# Altium schematic sheet Sheet6.SchDoc
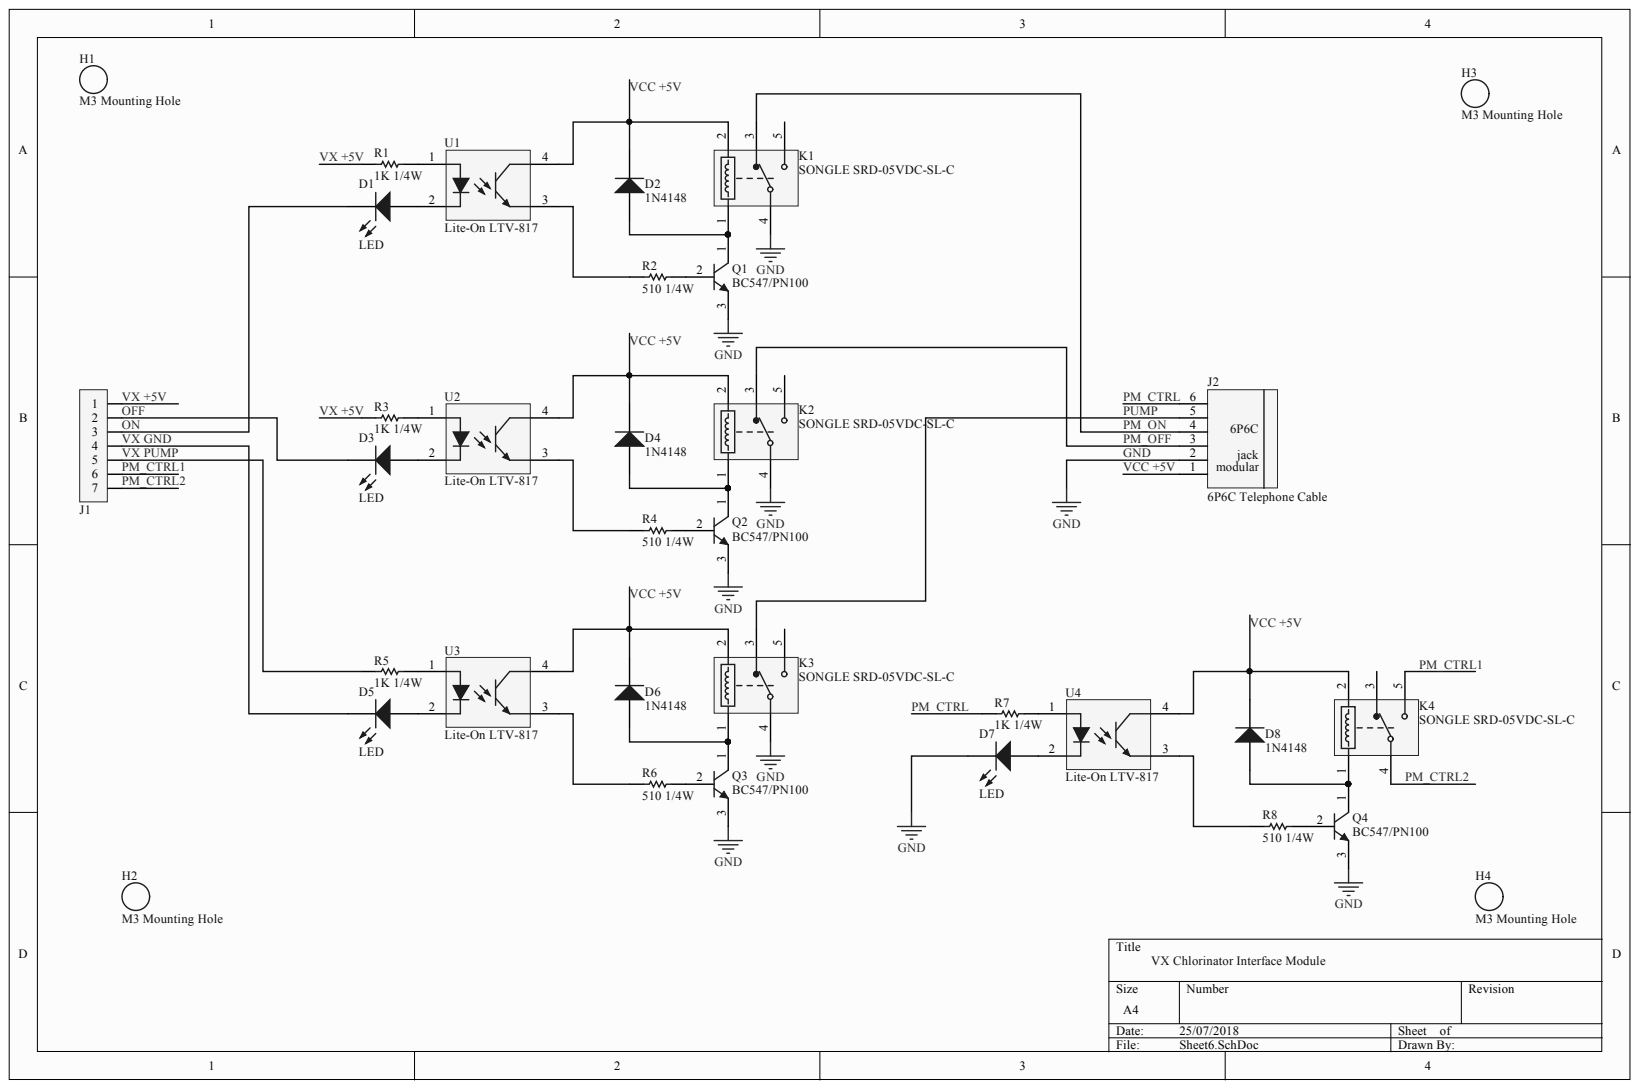
[diagram p0: Sheet6.SchDoc]

## Parts
D1  LED  [PCB-6hmn14p3euwrtpae4j9f-1]
D2  1N4148  [PCB-rs5tsfd40ie4dftnk2d5-1]
D3  LED  [PCB-6hmn14p3euwrtpae4j9f-1]
D4  1N4148  [PCB-rs5tsfd40ie4dftnk2d5-1]
D5  LED  [PCB-6hmn14p3euwrtpae4j9f-1]
D6  1N4148  [PCB-rs5tsfd40ie4dftnk2d5-1]
D7  LED  [PCB-6hmn14p3euwrtpae4j9f-1]
D8  1N4148  [PCB-rs5tsfd40ie4dftnk2d5-1]
H1  M3 Mounting Hole  [PCB-sb9r54v1ukudlsmquqqd-1]
H2  M3 Mounting Hole  [PCB-sb9r54v1ukudlsmquqqd-1]
H3  M3 Mounting Hole  [PCB-sb9r54v1ukudlsmquqqd-1]
H4  M3 Mounting Hole  [PCB-sb9r54v1ukudlsmquqqd-1]
J1  7pin header  [PCBComponent_1 - duplicate]  (value not drawn)
J2  6P6C Telephone Cable  [PCB-617631-1]
K1  SONGLE SRD-05VDC-SL-C  [PCB-g2fdo3sb6id1q29ao90z-1]
K2  SONGLE SRD-05VDC-SL-C  [PCB-g2fdo3sb6id1q29ao90z-1]
K3  SONGLE SRD-05VDC-SL-C  [PCB-g2fdo3sb6id1q29ao90z-1]
K4  SONGLE SRD-05VDC-SL-C  [PCB-g2fdo3sb6id1q29ao90z-1]
Q1  BC547/PN100  [ONSC-TO-92-3-29-11_AM_BL]
Q2  BC547/PN100  [ONSC-TO-92-3-29-11_AM_BL]
Q3  BC547/PN100  [ONSC-TO-92-3-29-11_AM_BL]
Q4  BC547/PN100  [ONSC-TO-92-3-29-11_AM_BL]
R1  1K 1/4W  [PCB-b0svi7yxgb64se8nuirk-1]
R2  510 1/4W  [PCB-b0svi7yxgb64se8nuirk-1]
R3  1K 1/4W  [PCB-b0svi7yxgb64se8nuirk-1]
R4  510 1/4W  [PCB-b0svi7yxgb64se8nuirk-1]
R5  1K 1/4W  [PCB-b0svi7yxgb64se8nuirk-1]
R6  510 1/4W  [PCB-b0svi7yxgb64se8nuirk-1]
R7  1K 1/4W  [PCB-b0svi7yxgb64se8nuirk-1]
R8  510 1/4W  [PCB-b0svi7yxgb64se8nuirk-1]
U1  Lite-On LTV-817  Manufacturer Part Number: LTV-817  [PCBComponent_1 - duplicate]
U2  Lite-On LTV-817  Manufacturer Part Number: LTV-817  [PCBComponent_1 - duplicate]
U3  Lite-On LTV-817  Manufacturer Part Number: LTV-817  [PCBComponent_1 - duplicate]
U4  Lite-On LTV-817  Manufacturer Part Number: LTV-817  [PCBComponent_1 - duplicate]

## Nets
GND: D7.2 J2.2 K1.4(COM) K2.4(COM) K3.4(COM) Q1.3 Q2.3 Q3.3 Q4.3
OFF: D3.2 J1.2
ON: D1.2 J1.3
PM_CTRL: J2.6 R7.2
PM_CTRL1: J1.6 K4.5(NO)
PM_CTRL2: J1.7 K4.4(COM)
PM_OFF: J2.3 K2.3(NC)
PM_ON: J2.4 K1.3(NC)
PUMP: J2.5 K3.3(NC)
VCC +5V: D2.2 D4.2 D6.2 D8.2 J2.1 K1.2(Coil2) K2.2(Coil2) K3.2(Coil2) K4.2(Coil2) U1.4 U2.4 U3.4 U4.4
VX +5V: J1.1 R1.2 R3.2
VX GND: D5.2 J1.4
VX PUMP: J1.5 R5.2
NetD1_1: D1.1 U1.2
NetD2_1: D2.1 K1.1(Coil1) Q1.1
NetD3_1: D3.1 U2.2
NetD4_1: D4.1 K2.1(Coil1) Q2.1
NetD5_1: D5.1 U3.2
NetD6_1: D6.1 K3.1(Coil1) Q3.1
NetD7_1: D7.1 U4.2
NetD8_1: D8.1 K4.1(Coil1) Q4.1
NetQ1_2: Q1.2 R2.2
NetQ2_2: Q2.2 R4.2
NetQ3_2: Q3.2 R6.2
NetQ4_2: Q4.2 R8.2
NetR1_1: R1.1 U1.1
NetR2_1: R2.1 U1.3
NetR3_1: R3.1 U2.1
NetR4_1: R4.1 U2.3
NetR5_1: R5.1 U3.1
NetR6_1: R6.1 U3.3
NetR7_1: R7.1 U4.1
NetR8_1: R8.1 U4.3

Not connected: K1 5(NO); K2 5(NO); K3 5(NO); K4 3(NC)

## Library data of the parts
D1 D3 D5 D7 = CMP-9d1f5758637d42d8-1: TLHR5205 Red LED, 625 nm 5mm (T-1 3/4), Round Lens Through Hole package; Forward Voltage: 2.00 V; Lead-Free Status: Lead Free; Lead Length: 24.0 mm; Luminous Intensity: 70.0 mcd; Peak Wavelength: 635 nm; Viewing Angle: 14 °; Wavelength: 625 nm; Color: Red; Component Kind: Standard; Library Name: CommunityVault; Manufacturer Part Number: TLHR5205; Mounting Style: Through Hole, Radial; Number of Pins: 2; OctopartID: 9d1f5758637d42d8; Octopart URL: http://octopart.com/tlhr5205-vishay-55433988; RoHS: Compliant; Voltage Rating (DC): 2.00 V
D2 D4 D6 D8 = CMP-814702-5: DIODE GEN PURP 100V 200MA DO35; Manufacturer: Fairchild Semiconductor; Capacitance: 4e-12 F; Forward Voltage: 1.0 V; Lead-Free Status: Lead Free; Polarity: Standard; Voltage Rating (DC): 100.0 V; Case/Package: DO-35; Component Kind: Standard; Current Rating: 200 mA; Library Name: CommunityVault; Lifecycle Status: Active; Manufacturer Part Number: 1N4148; Mounting Style: Through Hole; Number of Pins: 2; OctopartID: ae03670d61fd71c4; Octopart Url: https://octopart.com/1n4148-fairchild+semiconductor-322200; Packaging: Bulk; REACH SVHC Compliance: No SVHC; RoHS: Compliant
H1-H4 = CMP-2eajnev4pcr11g3c6yc7-1: M3 Mountig Hole; Component Kind: Standard; CustomComponentID: 2eajnev4pcr11g3c6yc7; Library Name: CommunityVault
J1 = CMP-b84c7047322f678b-1: Conn Unshrouded Header HDR 7 POS 2.54mm Solder ST Thru-Hole Bag; Component Kind: Standard; Library Name: CommunityVault
J2 = CMP-617631-5: CONN MOD JACK R/A 6P6C; Contact Resistance: 0.02 ?; Current Rating: 1.5 A; Gender: Male; Insulation Resistance: 500000000.0 ?; Number of Contacts: 6; Number of Mating Cycles: 500; Color: Black; Component Kind: Standard; Contact Material: Copper; Contact Plating: Gold; Flammability Rating: UL 94 V-0; Library Name: CommunityVault; Mounting Style: Through Hole; OctopartID: 186cd1ee2e20c7ab; Octopart Url: https://octopart.com/615006138421-w%C3%BCrth+elektronik-8917802; REACH SVHC Compliance: No SVHC; RoHS: Compliant
K1-K4 = CMP-t5gqq9hbd8q2y5oaholr-2: SONGLE SRD-05VDC-SL-C SPDT 5Volt Relay; Component Kind: Standard; CustomComponentID: t5gqq9hbd8q2y5oaholr; Library Name: CommunityVault; Manufacturer: Songle; Manufacturer Part Number: SRD-05VDC-SL-C
Q1-Q4 = CMP-860851-2: Amplifier Transistor, NPN Silicon, 3-Pin TO-92, Pb-Free, Ammo Box; Current Rating: 0.1 A; Lead-Free Status: Lead Free; Power Dissipation: 0.625 W; Voltage Rating (DC): 45.0 V; Case/Package: TO-92; Component Kind: Standard; Library Name: CommunityVault; Lifecycle Status: Active; Mounting Style: Through Hole; Number of Pins: 3; OctopartID: 3009f917f9f0efce; Octopart Url: https://octopart.com/bc547bzl1g-on+semiconductor-1371295; Packaging: Tape; Polarity: NPN; REACH SVHC Compliance: No SVHC; RoHS: Compliant
R1-R8 = CMP-b3d47a8ce28e6c13-3: Resistor; Metal Film; Res 1 Kilohms; Pwr-Rtg 0.25 W; Tol 0.1%; Axial; TCR 15 ppm/DegC; Manufacturer Part Number: YR1B1K0CC; ELV Compliant: Compliant; Lead Length: 25.0 mm; Temperature Coefficient: -15.0 ppm/°C to 15.0 ppm/°C; Voltage Rating (DC): 500 V; Component Kind: Standard; Library Name: CommunityVault; Lifecycle Status: Active; Mounting Style: Axial; Number of Pins: 2; OctopartID: b3d47a8ce28e6c13; Octopart URL: https://octopart.com/yr1b1k0cc-te+connectivity-5403708; Operating Temperature: -65.0 °C to 155 °C; Packaging: Ammo Pack; REACH SVHC Compliance: No SVHC; Resistance Tolerance: ±0.1%; RoHS: Compliant
U1-U4 = CMP-1524854-5: LTV Series Single Channel 35 V 5000 Vrms Transistor Output Optocoupler - DIP-4; Input Voltage (DC): 1.20 V; Isolation Voltage: 5.00 kV; Lead-Free Status: Lead Free; Number of Channels: 1; Output Voltage: 35.0 V (max); Rise Time: 4.00 µs; Case/Package: DIP; Component Kind: Standard; Library Name: CommunityVault; Mounting Style: Through Hole; Number of Circuits: 1; Number of Pins: 4; OctopartID: 1951d05182d8c696; Octopart URL: http://octopart.com/ltv-817-lite-on-704239; Packaging: Tube; RoHS: Compliant
